# Altium schematic sheet [01]_STM32.SchDoc([01]_STM32)
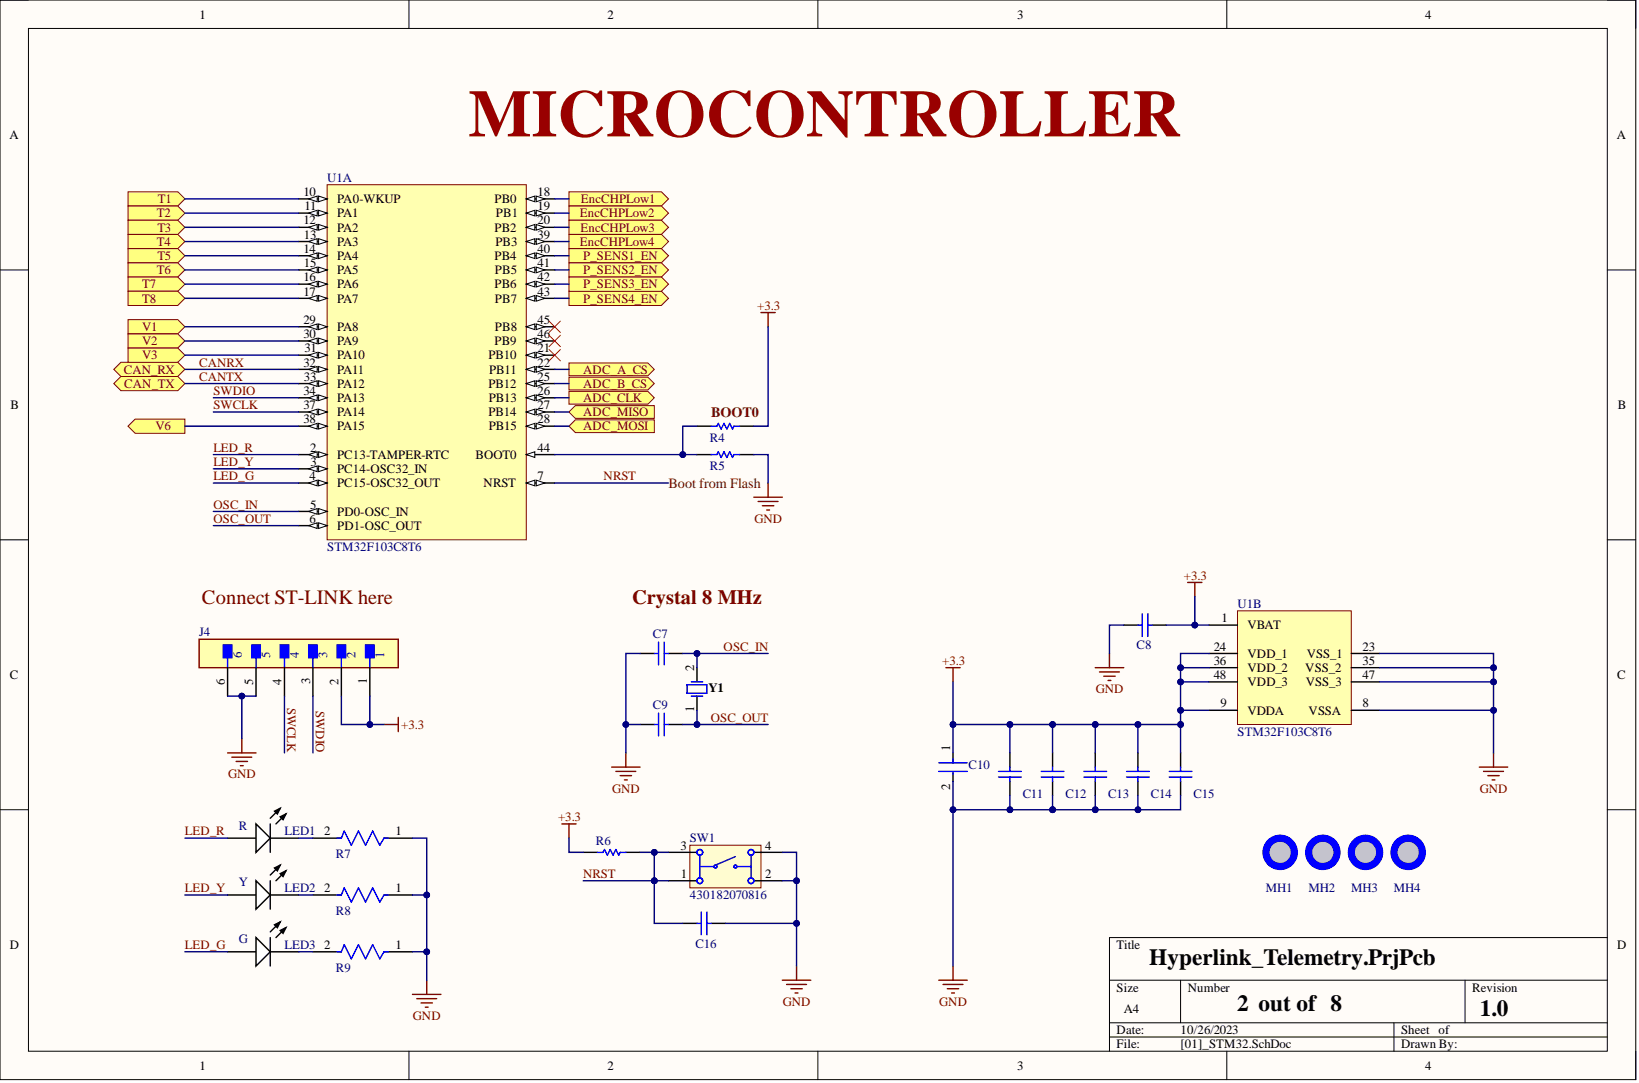
[diagram p0: [01]_STM32.SchDoc]

## Parts
C7  CC0603JRNPO9BN100  (value not drawn)
C8  GRM188R71H104KA93D  (value not drawn)
C9  CC0603JRNPO9BN100  (value not drawn)
C10  TMK107BBJ475KA-T  (value not drawn)
C11  GRM188R71H104KA93D  (value not drawn)
C12  GRM188R71H104KA93D  (value not drawn)
C13  GRM188R71H104KA93D  (value not drawn)
C14  GRM188R71H104KA93D  (value not drawn)
C15  GRM188R71H104KA93D  (value not drawn)
C16  GRM188R71H104KA93D  (value not drawn)
J4  901210766  (value not drawn)
LED1  R
LED2  Y
LED3  G
MH1  MH_7.4MMNC_3.2MMNC  (value not drawn)  (no BOM)
MH2  MH_7.4MMNC_3.2MMNC  (value not drawn)  (no BOM)
MH3  MH_7.4MMNC_3.2MMNC  (value not drawn)  (no BOM)
MH4  MH_7.4MMNC_3.2MMNC  (value not drawn)  (no BOM)
R4  CRCW06030000Z0EA  (value not drawn)  (no BOM)
R5  CRCW06030000Z0EA  (value not drawn)
R6  RC0603JR-0710KL  (value not drawn)
R7  CRCW06031K00FKEA  (value not drawn)
R8  CRCW06031K00FKEA  (value not drawn)
R9  CRCW06031K00FKEA  (value not drawn)
SW1  430182070816
U1  STM32F103C8T6  (parts A,B)
Y1  FOXSDLF/080-20  (value not drawn)

## Ports
ADC_A_CS, ADC_B_CS, ADC_CLK, ADC_MISO, ADC_MOSI, CAN_RX, CAN_TX, EncCHPLow1, EncCHPLow2, EncCHPLow3, EncCHPLow4, P_SENS1_EN, P_SENS2_EN, P_SENS3_EN, P_SENS4_EN, T1, T2, T3, T4, T5, T6, T7, T8, V1, V2, V3, V6

## Nets
+3.3: C8.1 C10.1 C11.2 C12.2 C13.2 C14.2 C15.2 J4.1 J4.2 R4.1 R6.1 U1.1(VBAT) U1.9(VDDA) U1.24(VDD_1) U1.36(VDD_2) U1.48(VDD_3)
GND: C7.1 C8.2 C9.1 C10.2 C11.1 C12.1 C13.1 C14.1 C15.1 C16.1 J4.5 J4.6 R5.1 R7.1 R8.1 R9.1 SW1.2 SW1.4 U1.8(VSSA) U1.23(VSS_1) U1.35(VSS_2) U1.47(VSS_3)
ADC_A_CS: U1.22(PB11) ; port ADC_A_CS
ADC_B_CS: U1.25(PB12) ; port ADC_B_CS
ADC_CLK: U1.26(PB13) ; port ADC_CLK
ADC_MISO: U1.27(PB14) ; port ADC_MISO
ADC_MOSI: U1.28(PB15) ; port ADC_MOSI
CANRX: U1.32(PA11) ; port CAN_RX
CANTX: U1.33(PA12) ; port CAN_TX
EncCHPLow1: U1.18(PB0) ; port EncCHPLow1
EncCHPLow2: U1.19(PB1) ; port EncCHPLow2
EncCHPLow3: U1.20(PB2) ; port EncCHPLow3
EncCHPLow4: U1.39(PB3) ; port EncCHPLow4
LED_G: LED3.1 U1.4(PC15-OSC32_OUT)
LED_R: LED1.1 U1.2(PC13-TAMPER-RTC)
LED_Y: LED2.1 U1.3(PC14-OSC32_IN)
NRST: C16.2 R6.2 SW1.1 SW1.3 U1.7(NRST)
OSC_IN: C7.2 U1.5(PD0-OSC_IN) Y1.2
OSC_OUT: C9.2 U1.6(PD1-OSC_OUT) Y1.1
P_SENS1_EN: U1.40(PB4) ; port P_SENS1_EN
P_SENS2_EN: U1.41(PB5) ; port P_SENS2_EN
P_SENS3_EN: U1.42(PB6) ; port P_SENS3_EN
P_SENS4_EN: U1.43(PB7) ; port P_SENS4_EN
SWCLK: J4.4 U1.37(PA14)
SWDIO: J4.3 U1.34(PA13)
T1: U1.10(PA0-WKUP) ; port T1
T2: U1.11(PA1) ; port T2
T3: U1.12(PA2) ; port T3
T4: U1.13(PA3) ; port T4
T5: U1.14(PA4) ; port T5
T6: U1.15(PA5) ; port T6
T7: U1.16(PA6) ; port T7
T8: U1.17(PA7) ; port T8
V1: U1.29(PA8) ; port V1
V2: U1.30(PA9) ; port V2
V3: U1.31(PA10) ; port V3
V6: U1.38(PA15) ; port V6
NetLED1_2: LED1.2 R7.2
NetLED2_2: LED2.2 R8.2
NetLED3_2: LED3.2 R9.2
NetR4_2: R4.2 R5.2 U1.44(BOOT0)

Not connected: U1 21(PB10) 45(PB8) 46(PB9)

## Library data of the parts
C7 C9 = CMP-2100-03593-1: Chip Capacitor, 10 pF, +/- 5%, 50 V, -55 to 125 degC, 0603 (1608 Metric), RoHS, Tape and Reel; Manufacturer: Yageo; Capacitance: 10pF; Dielectric: C0G; Insulation Resistance: 100GR; Temperature Coefficient: 30ppm/degC; Voltage: 50V; Case Code (Imperial): 0603; Case Code (Metric): 1608; Case/Package: 0603; Component Kind: Standard; Composition: Ceramic; Datasheet URL: http://www.yageo.com/documents/recent/UPY-GP_NP0_16V-to-50V_14.pdf; Datasheet Version: Rev. 14, 07/2016; Depth: 0.8mm; Height: 0.8mm; JLC: C1634; Lead Free: LeadFree; Length: 1.6mm; Library Name: Altium Content Vault; Manufacturer URL: http://www.yageo.com/; Max Operating Temperature: 125degC; Min Operating Temperature: -55degC; Mount: SurfaceMount; Number of Pins: 2; Package Description: 2-Pin Surface Mount Device, Body 1.6 x 0.8 mm; Package Quantity: 1; Package Reference: 0603; Packaging: TapeandReel; Radiation Hardening: No; REACH SVHC: NoSVHC; RoHSCompliant: True; Termination: SMD/SMT; Thickness: 0.035inch; Tolerance: 5%; Voltage Rating: 50V; Voltage Rating (DC): 50V; Width: 0.031inch
C8 C11-C16 = CMP-2100-03643-1: Chip Capacitor, 0.1 uF, +/- 10%, 50 V, -55 to 125 degC, 0603 (1608 Metric), RoHS, Tape and Reel; Manufacturer: Murata; Capacitance: 100nF; Dielectric: X7R; Dielectric Material: Ceramic; Insulation Resistance: 10GR; Voltage: 50V; Case Code (Imperial): 0603; Case Code (Metric): 1608; Case/Package: 0603; Component Kind: Standard; Contact Plating: Tin; Datasheet URL: http://psearch.en.murata.com/capacitor/product/GRM188R71H104KA93%23.pdf; Datasheet Version: 42675.0; Depth: 0.8mm; Height: 0.8mm; JLC: C14663; Lead Free: LeadFree; Length: 1.6mm; Library Name: Altium Content Vault; Manufacturer URL: http://www.murata.com/; Material: Ceramic; Max Operating Temperature: 125degC; Min Operating Temperature: -55degC; Mount: SurfaceMount; Number of Pins: 2; Package Description: 2-Pin Surface Mount Device, Body 1.6 x 0.8 mm; Package Quantity: 1; Package Reference: 0603; Packaging: TapeandReel; Radiation Hardening: No; REACH SVHC: NoSVHC; RoHSCompliant: true; Termination: SMD/SMT; Thickness: 0.035inch; Tolerance: 10%; Voltage Rating: 50V; Voltage Rating (DC): 50V; Width: 0.8mm
C10 = CMP-14477-004926-2: Multilayer Ceramic Capacitor 4.7uF 25V X5R 10% SMD 0603 T/R; Capacitance: 4.7uF; Case Code (Imperial): 0603; Case Code (Metric): 1608; Case/Package: 0603; Component Kind: Standard; Contact Plating: Tin; Dielectric: X5R; JLC: C19666; Lead Free: Lead Free; Length: 0.063inch; Library Name: Altium Content Vault; Max Operating Temperature: 85°C; Min Operating Temperature: -55°C; Mount: Surface Mount; Packaging: Tape and Reel; RoHS Compliant: Yes; Termination: Wraparound; Thickness: 0.039inch; Tolerance: 10%; Voltage Rating: 25V; Width: 0.031inch
J4 = CMP-04776-000096-1: CONN HEADER R/A 6POS 2.54MM; Manufacturer: Molex; Conn Gender: Male; Conn Orientation: Right Angle; Current Rating: 3A; Number Of Contacts: 6; Voltage Rating: 350V; Automotive: No; Category: Conn; Component Kind: Standard; ComponentLink2Description: Datasheet; ComponentLink2URL: https://www.molex.com/webdocs/datasheets/pdf/en-us/0901210766_PCB_HEADERS.pdf; Contact Material: Gold,Nickel; Device Class L1: Connectors; Device Class L2: Headers and Wire Housings; Device Class L3: unset; Digikey Description: CONN HEADER R/A 6POS 2.54MM; Footprint Url: https://www.molex.com/pdm_docs/sd/901210766_sd.pdf; Height: 3.6mm; JLC: C492414; Lead Free: Yes; Library Name: Altium Content Vault; Manufacturer Part Number: 901210766; Number Of Rows: 1; Octopart: https://octopart.com/90121-0766-molex-655456; Package: 0; Pitch: 2.54mm; RoHS: TRUE; Temperature: -55°C to +125°C; Temperature Range High: +125°C; Temperature Range Low: -55°C
LED1-LED3 = CMP-1744-00001-1: LED Uni-Color GREEN, 78 mW, 20 mA, -40 to 85 degC, 2-Pin SMD, RoHS, Tape and Reel; Current Rating: 300 mA; Component Kind: Standard; ComponentLink1Description: Manufacturer URL; ComponentLink1URL: http://www.avagotech.com/; ComponentLink2Description: Datasheet; ComponentLink2URL: http://docs.avagotech.com/docs/AV02-0186EN; Contact Finish: Silver; Contact Material: Copper; Contact Plating: Silver; DatasheetVersion: May-10; Height: 12 mm; Length: 16 mm; Library Name: Altium Content Vault; Max Operating Temperature: 85 degC; Min Operating Temperature: -20 degC; Mount: Through Hole; Package Quantity: 560; PackageDescription: 2-Pin Surface Mount Device, Body 1.6 x 0.8 mm; PackageReference: HSMW-C191; Packaging: Bulk; Radiation Hardening: No; REACH SVHC: Unknown; RoHSCompliant: true; Width: 12 mm
  LED1: JLC: C72044
  LED2: JLC: C72038
  LED3: JLC: C72043
MH1-MH4 = MH_7.4MMNC_3.2MMNC: Hole 7.4mm Diameter (No Copper), 3.2mm Drill NPTH; Library Name: Hyperlink_Int_Library.IntLib
R4 R5 = CMP-2000-03816-5: Chip Resistor, 0 Ohm, Jumper, 100 mW, -55 to 155 degC, 0603 (1608 Metric), RoHS, Tape and Reel; Manufacturer: Vishay Semiconductor; Voltage Rating: 75 V; ComponentLink1Description: Manufacturer URL; ComponentLink1URL: http://www.vishay.com/; ComponentLink2Description: Datasheet; ComponentLink2URL: http://www.vishay.com/docs/20035/dcrcwe3.pdf; DatasheetVersion: 06/2012; Height: 0.45 mm; JLC: C21189; Length: 1.55 mm; Library Name: Altium Content Vault; Max Operating Temperature: 155 degC; Min Operating Temperature: -55 degC; Mount: Surface Mount; Mounting Technology: Surface Mount; Package Quantity: 5000; PackageDescription: 2-Pin Surface Mount Device, Body 1.575 x 0.85 mm; PackageReference: 0603; Packaging: Tape and Reel; Pins: 2; REACH SVHC: Unknown; RoHSCompliant: true
  R5: Component Kind: Standard
R6 = CMP-2000-05022-1: Resistor, 10 KOhm, +/- 5%, 100 mW, -55 to 155 degC, 0603 (1608 Metric), RoHS, Tape and Reel; Manufacturer: Yageo; Power Rating: 100mW; Resistance: 10kOhms; Temperature Coefficient: 100PPM/C; Voltage Rating: 50V; Case Code - in: 0603; Case Code - mm: 1608; Component Kind: Standard; Datasheet URL: http://www.farnell.com/datasheets/443971.pdf; Datasheet Version: Rev. 4, 04/2009; JLC: C25804; Library Name: Altium Content Vault; Manufacturer URL: http://www.yageo.com/; Operating Temperature Range: -55Cto+155C; Package Description: 2-Pin Surface Mount Device, Body 1.6 x 0.8 mm; Package Reference: 603; Packaging: Reel; Product: ThickFilmResistorsGeneralPurpose; Series: RC; Termination Style: SMD/SMT; Tolerance: 5%
R7-R9 = CMP-1666-00003-5: RES Thick Film, 1kΩ, 1%, 0.1W, 100ppm/°C, 0603; Max Power Dissipation: 100mW; Resistance: 1kR; Voltage Rating: 75V; Case Code (Imperial): 0603; Case Code (Metric): 1608; Case/Package: 0603; Component Kind: Standard; Composition: Thick Film; Contact Plating: Tin; Depth: 0.85mm; Features: Automotive AEC-Q200; Height: 0.45mm; JLC: C21190; Lead Free: Lead Free; Length: 1.55mm; Library Name: Altium Content Vault; Max Operating Temperature: 155°C; Military Standard: Not; Min Operating Temperature: -55°C; Mount: Surface Mount; Number of Pins: 2; Number of Terminations: 2; Package Quantity: 10; Packaging: Tape and Reel; Power Rating: 100mW; Radiation Hardening: No; Temperature Coefficient: 100ppm/°C; Termination: SMD/SMT; Tolerance: 1%; Voltage Rating (DC): 75V; Weight: 7.1E-05oz; Width: 0.033inch
SW1 = CMP-1452-00015-1: WS-TASV SMD Tact Switch 6X6 mm; Manufacturer: Wuerth Elektronik; NoPins: 04; Component Kind: Standard; ComponentLink1Description: Manufacturer URL; ComponentLink1URL: http://www.we-online.com; ComponentLink2Description: Datasheet; ComponentLink2URL: http://katalog.we-online.de/em/datasheet/4301x2.pdf; JLC: C620316; Library Name: Altium Content Vault; PackageReference: 430182070816; PartNumber: 430182070816
U1 = CMP-0237-00215-3: ARM Cortex-M3 32-bit MCU, 64 KB Flash, 20 KB Internal RAM, 37 I/Os, 48-pin LQFP, -40 to 85 degC, Tray; A/D Converter: 2x12-bit (10 Channel); FLASH Size (Prog) (kB): 64; I/Os (High Current): 37; Internal RAM Size (kB): 20; Operating Frequency(F) (Processor speed) (MHz): 72; Supply Voltage(Vcc) min (V): 2; Component Kind: Standard; ComponentLink1Description: Manufacturer URL; ComponentLink1URL: http://www.st.com/web/en/home.html; ComponentLink2Description: Datasheet; ComponentLink2URL: http://www.st.com/st-web-ui/static/active/en/resource/technical/document/datasheet/CD00161566.pdf; ComponentLink3Description: Package Specification; ComponentLink3URL: http://www.st.com/st-web-ui/static/active/en/resource/technical/document/datasheet/DM00058181.pdf; Core: ARM Cortex-M3; DatasheetVersion: Rev. 16; JLC: C8734; Library Name: Altium Content Vault; Mounting Technology: Surface Mount; PackageDescription: 48-Pin Low Profile Quad Flat Package, 7 x 7 mm Body, 0.5 mm Pitch; PackageReference: LQFP48; PackageVersion: Rev. 6, 06/2013; Packing: Tray; PartNumber: STM32F103C8T6
Y1 = CMP-2100-03639-1: Resistance Weld Crystal, 8 MHz, +/- 30 ppm, -20 to 70 degC, 2-Pin SMD, RoHS, Tape and Reel; Manufacturer: FOX Electronics; ESR (Equivalent Series Resistance): 80Ohm; Frequency: 8MHz; Frequency Stability: 50ppm; Frequency Tolerance: 30ppm; Load Capacitance: 20pF; Case/Package: SMD/SMT; Component Kind: Standard; Datasheet URL: http://www.foxonline.com/pdfs/hc49sdlf.pdf; Datasheet Version: 42064.0; Depth: 5mm; Height: 4.5mm; JLC: C12674; Lead Free: LeadFree; Length: 11.7mm; Library Name: Altium Content Vault; Manufacturer URL: http://www.foxonline.com/; Max Operating Temperature: 70degC; Min Operating Temperature: -20degC; Mount: SurfaceMount; Number of Pins: 2; Package Description: 2-Pin Surface Mount Device, Body 5 x 11.7 mm; Package Quantity: 1000; Package Reference: HC49SDLF; Packaging: TapeandReel; Radiation Hardening: No; REACH SVHC: NoSVHC; RoHSCompliant: True; Series Resistance: 80Ohm; Termination: SMD/SMT; Tolerance: 50ppm; Width: 5mm
